# Altium schematic sheet one_side_term_J4.SchDoc
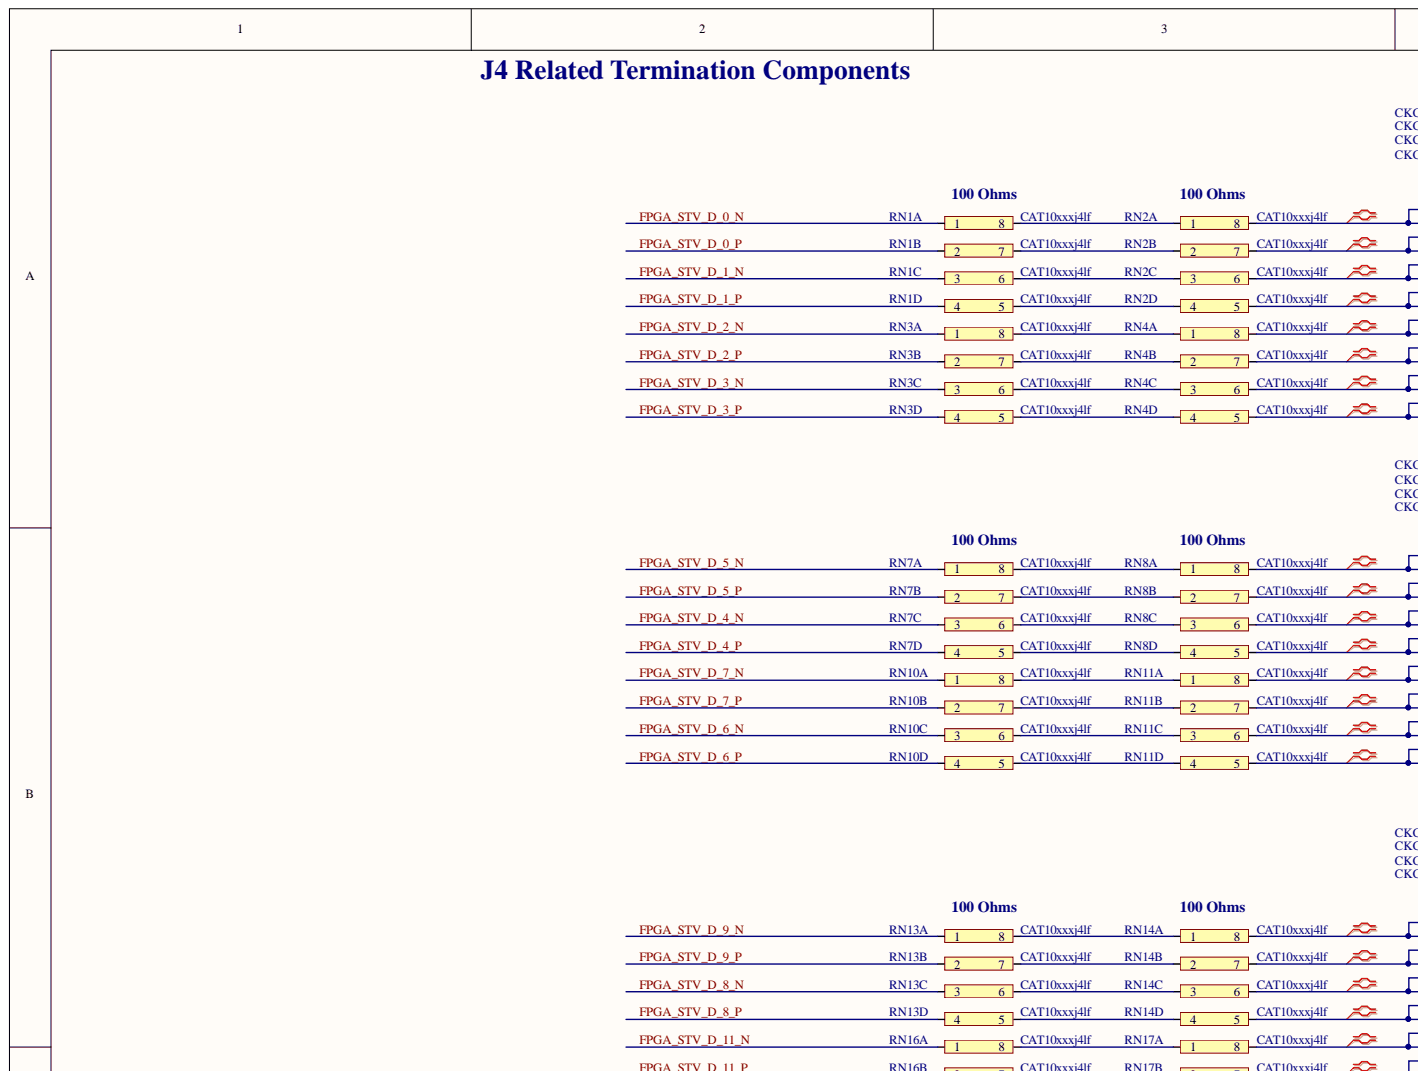
[diagram p0: one_side_term_J4.SchDoc, top left]
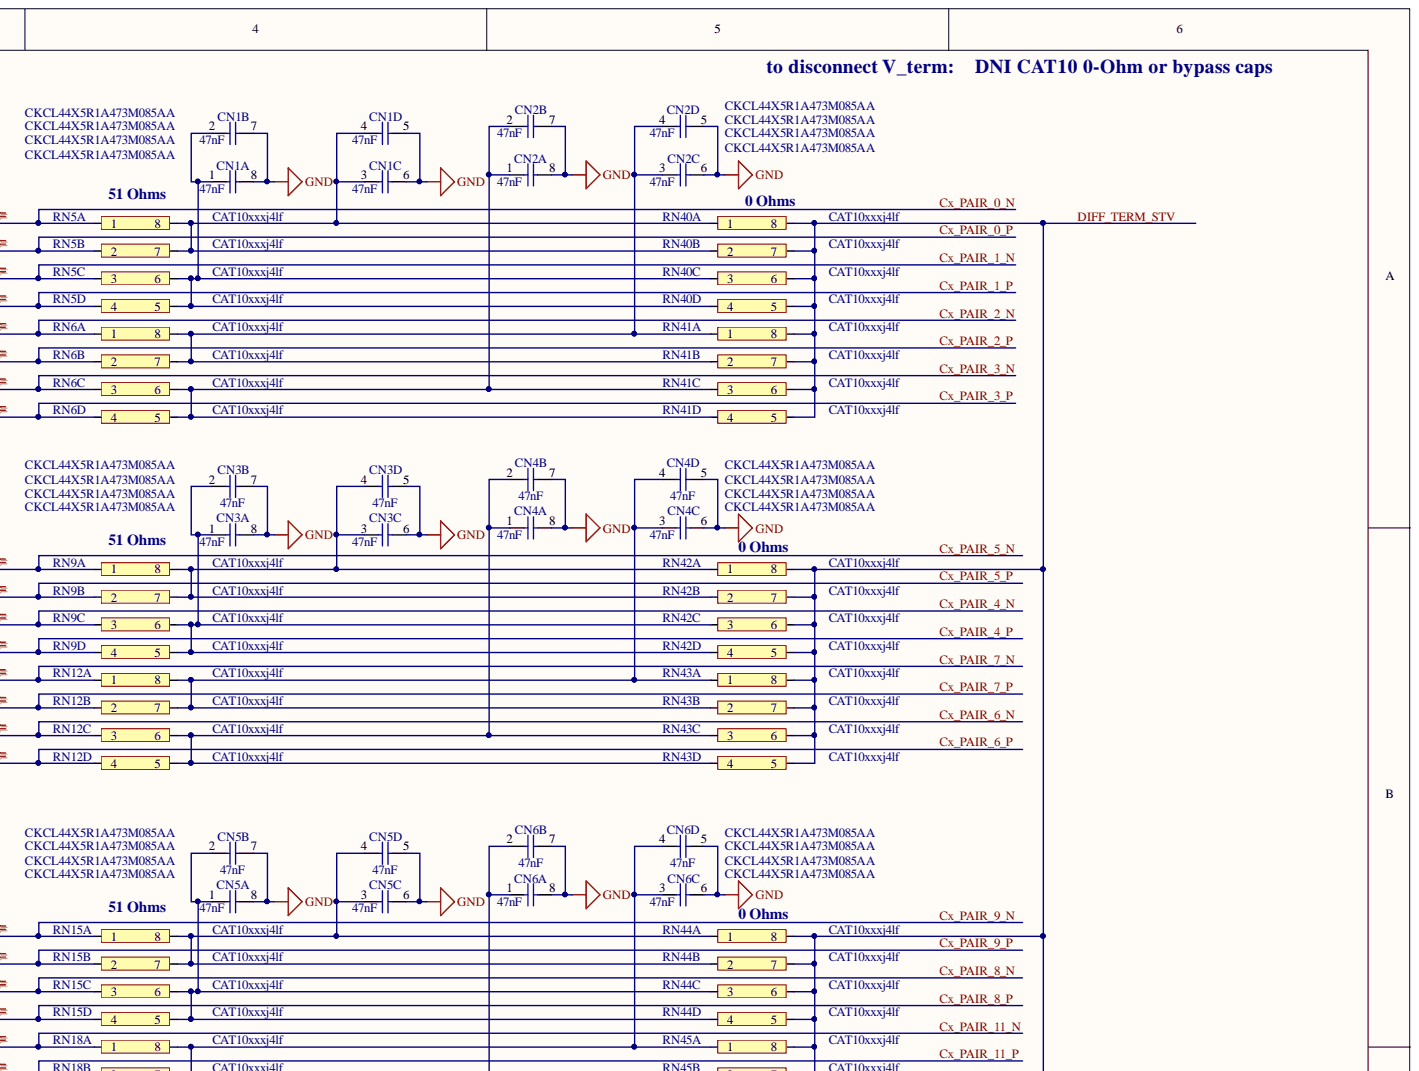
[diagram p1: one_side_term_J4.SchDoc, top right]
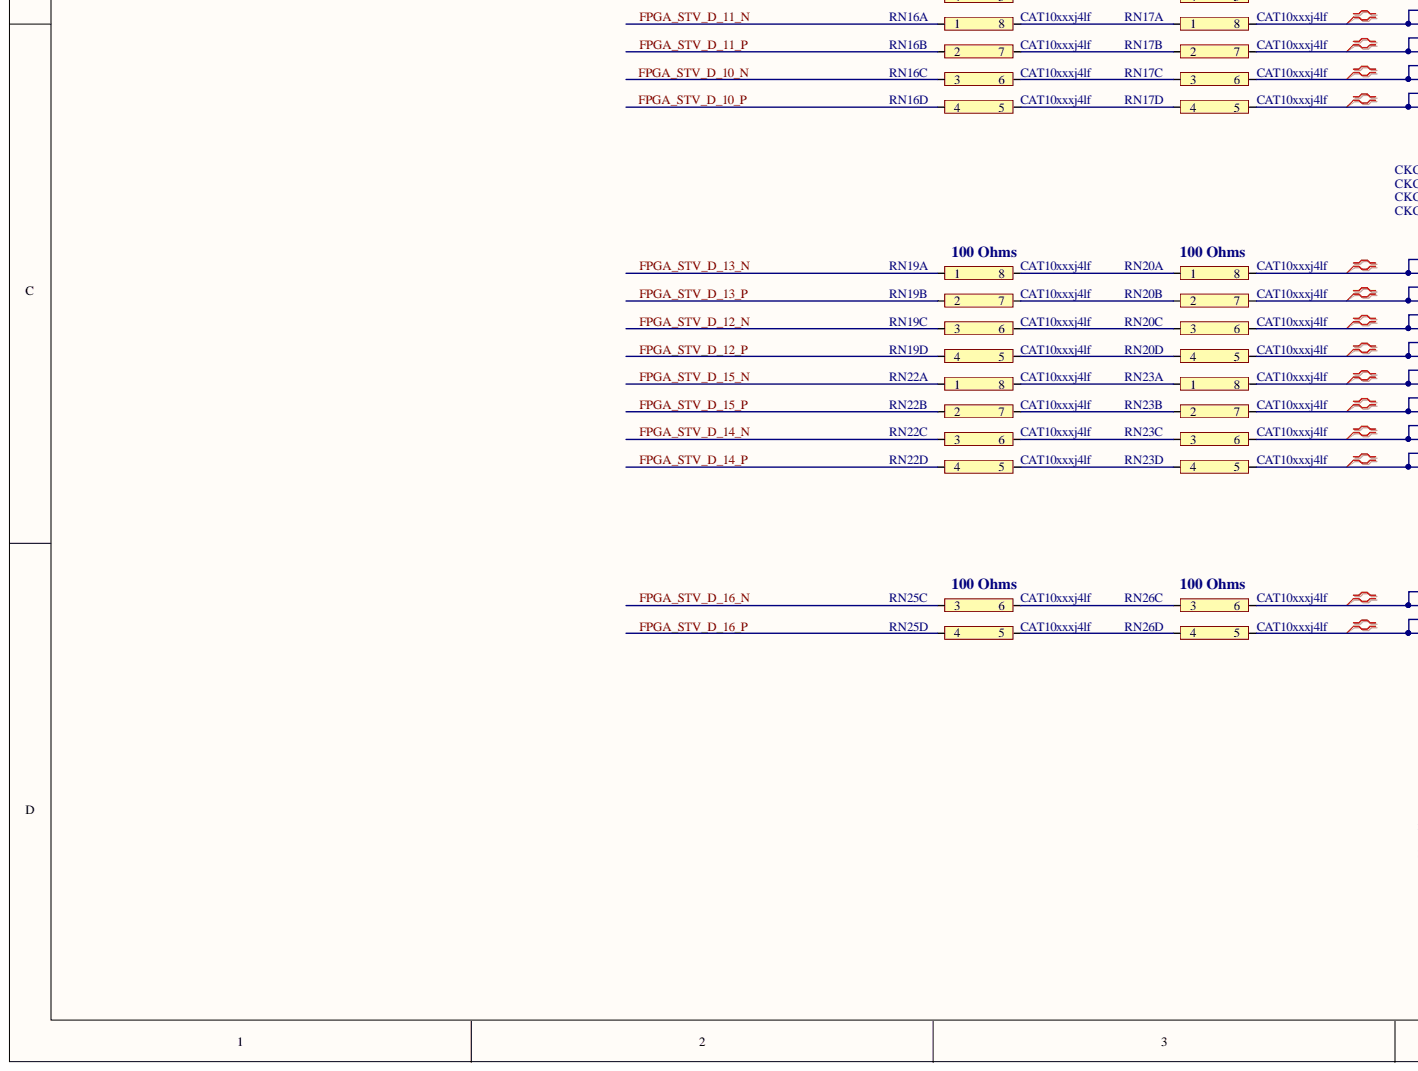
[diagram p2: one_side_term_J4.SchDoc, bottom left]
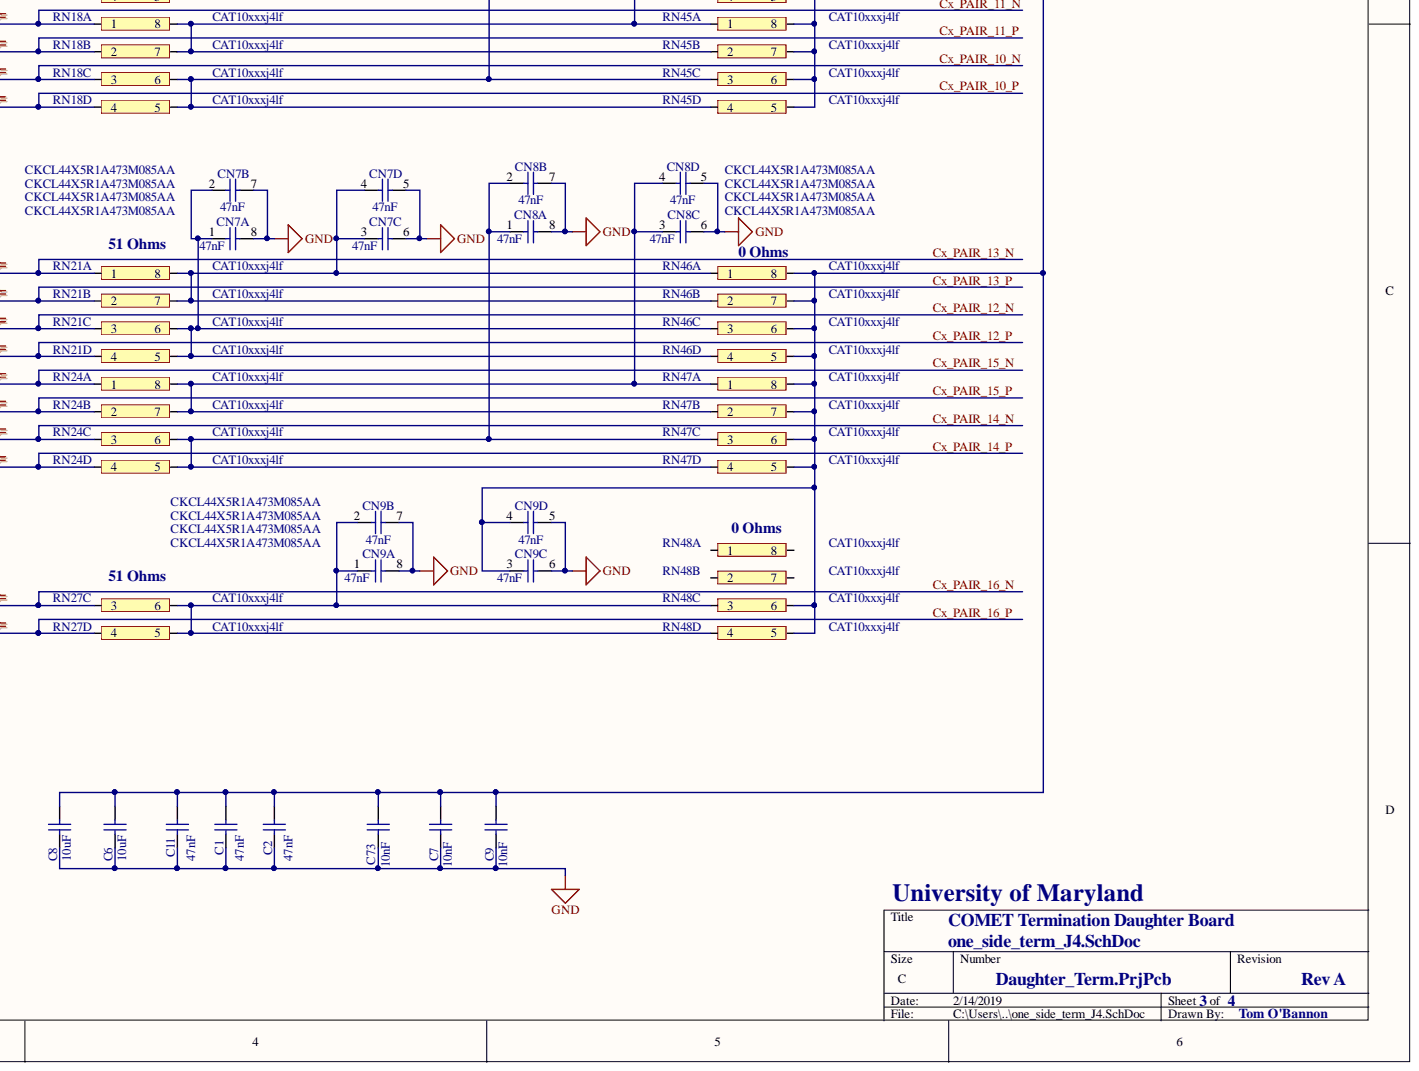
[diagram p3: one_side_term_J4.SchDoc, bottom right]

## Parts
C1  47nF  [CAPC2012X135N]
C2  47nF  [CAPC2012X135N]
C6  10uF  [CAPC2012X135N]
C7  10nF  [CAPC2012X135N]
C8  10uF  [CAPC2012X135N]
C9  10nF  [CAPC2012X135N]
C11  47nF  [CAPC2012X135N]
C73  10nF  [CAPC2012X135N]
CN1  47nF  Manufacturer Part Number: CKCL44X5R1A473M085AA  [CAPCAF50P125X200X100-8N]  (parts A,B,C,D)
CN2  47nF  Manufacturer Part Number: CKCL44X5R1A473M085AA  [CAPCAF50P125X200X100-8N]  (parts A,B,C,D)
CN3  47nF  Manufacturer Part Number: CKCL44X5R1A473M085AA  [CAPCAF50P125X200X100-8N]  (parts A,B,C,D)
CN4  47nF  Manufacturer Part Number: CKCL44X5R1A473M085AA  [CAPCAF50P125X200X100-8N]  (parts A,B,C,D)
CN5  47nF  Manufacturer Part Number: CKCL44X5R1A473M085AA  [CAPCAF50P125X200X100-8N]  (parts A,B,C,D)
CN6  47nF  Manufacturer Part Number: CKCL44X5R1A473M085AA  [CAPCAF50P125X200X100-8N]  (parts A,B,C,D)
CN7  47nF  Manufacturer Part Number: CKCL44X5R1A473M085AA  [CAPCAF50P125X200X100-8N]  (parts A,B,C,D)
CN8  47nF  Manufacturer Part Number: CKCL44X5R1A473M085AA  [CAPCAF50P125X200X100-8N]  (parts A,B,C,D)
CN9  47nF  Manufacturer Part Number: CKCL44X5R1A473M085AA  [CAPCAF50P125X200X100-8N]  (parts A,B,C,D)
RN1  CAT10xxxj4lf  [CAT10xxxj4lf]  (parts A,B,C,D)
RN2  CAT10xxxj4lf  [CAT10xxxj4lf]  (parts A,B,C,D)
RN3  CAT10xxxj4lf  [CAT10xxxj4lf]  (parts A,B,C,D)
RN4  CAT10xxxj4lf  [CAT10xxxj4lf]  (parts A,B,C,D)
RN5  CAT10xxxj4lf  [CAT10xxxj4lf]  (parts A,B,C,D)
RN6  CAT10xxxj4lf  [CAT10xxxj4lf]  (parts A,B,C,D)
RN7  CAT10xxxj4lf  [CAT10xxxj4lf]  (parts A,B,C,D)
RN8  CAT10xxxj4lf  [CAT10xxxj4lf]  (parts A,B,C,D)
RN9  CAT10xxxj4lf  [CAT10xxxj4lf]  (parts A,B,C,D)
RN10  CAT10xxxj4lf  [CAT10xxxj4lf]  (parts A,B,C,D)
RN11  CAT10xxxj4lf  [CAT10xxxj4lf]  (parts A,B,C,D)
RN12  CAT10xxxj4lf  [CAT10xxxj4lf]  (parts A,B,C,D)
RN13  CAT10xxxj4lf  [CAT10xxxj4lf]  (parts A,B,C,D)
RN14  CAT10xxxj4lf  [CAT10xxxj4lf]  (parts A,B,C,D)
RN15  CAT10xxxj4lf  [CAT10xxxj4lf]  (parts A,B,C,D)
RN16  CAT10xxxj4lf  [CAT10xxxj4lf]  (parts A,B,C,D)
RN17  CAT10xxxj4lf  [CAT10xxxj4lf]  (parts A,B,C,D)
RN18  CAT10xxxj4lf  [CAT10xxxj4lf]  (parts A,B,C,D)
RN19  CAT10xxxj4lf  [CAT10xxxj4lf]  (parts A,B,C,D)
RN20  CAT10xxxj4lf  [CAT10xxxj4lf]  (parts A,B,C,D)
RN21  CAT10xxxj4lf  [CAT10xxxj4lf]  (parts A,B,C,D)
RN22  CAT10xxxj4lf  [CAT10xxxj4lf]  (parts A,B,C,D)
RN23  CAT10xxxj4lf  [CAT10xxxj4lf]  (parts A,B,C,D)
RN24  CAT10xxxj4lf  [CAT10xxxj4lf]  (parts A,B,C,D)
RN25  CAT10xxxj4lf  [CAT10xxxj4lf]  (parts C,D)
RN26  CAT10xxxj4lf  [CAT10xxxj4lf]  (parts C,D)
RN27  CAT10xxxj4lf  [CAT10xxxj4lf]  (parts C,D)
RN40  CAT10xxxj4lf  [CAT10xxxj4lf]  (parts A,B,C,D)
RN41  CAT10xxxj4lf  [CAT10xxxj4lf]  (parts A,B,C,D)
RN42  CAT10xxxj4lf  [CAT10xxxj4lf]  (parts A,B,C,D)
RN43  CAT10xxxj4lf  [CAT10xxxj4lf]  (parts A,B,C,D)
RN44  CAT10xxxj4lf  [CAT10xxxj4lf]  (parts A,B,C,D)
RN45  CAT10xxxj4lf  [CAT10xxxj4lf]  (parts A,B,C,D)
RN46  CAT10xxxj4lf  [CAT10xxxj4lf]  (parts A,B,C,D)
RN47  CAT10xxxj4lf  [CAT10xxxj4lf]  (parts A,B,C,D)
RN48  CAT10xxxj4lf  [CAT10xxxj4lf]  (parts A,B,C,D)

## Nets
GND: C1.1 C2.1 C6.1 C7.1 C8.1 C9.1 C11.1 C73.1 CN1.5 CN1.6 CN1.7 CN1.8 CN2.5 CN2.6 CN2.7 CN2.8 CN3.5 CN3.6 CN3.7 CN3.8 CN4.5 CN4.6 CN4.7 CN4.8 CN5.5 CN5.6 CN5.7 CN5.8 CN6.5 CN6.6 CN6.7 CN6.8 CN7.5 CN7.6 CN7.7 CN7.8 CN8.5 CN8.6 CN8.7 CN8.8 CN9.5 CN9.6 CN9.7 CN9.8
CX_PAIR_0_N: RN2.8 RN5.1
CX_PAIR_0_P: RN2.7 RN5.2
CX_PAIR_1_N: RN2.6 RN5.3
CX_PAIR_1_P: RN2.5 RN5.4
CX_PAIR_2_N: RN4.8 RN6.1
CX_PAIR_2_P: RN4.7 RN6.2
CX_PAIR_3_N: RN4.6 RN6.3
CX_PAIR_3_P: RN4.5 RN6.4
CX_PAIR_4_N: RN8.6 RN9.3
CX_PAIR_4_P: RN8.5 RN9.4
CX_PAIR_5_N: RN8.8 RN9.1
CX_PAIR_5_P: RN8.7 RN9.2
CX_PAIR_6_N: RN11.6 RN12.3
CX_PAIR_6_P: RN11.5 RN12.4
CX_PAIR_7_N: RN11.8 RN12.1
CX_PAIR_7_P: RN11.7 RN12.2
CX_PAIR_8_N: RN14.6 RN15.3
CX_PAIR_8_P: RN14.5 RN15.4
CX_PAIR_9_N: RN14.8 RN15.1
CX_PAIR_9_P: RN14.7 RN15.2
CX_PAIR_10_N: RN17.6 RN18.3
CX_PAIR_10_P: RN17.5 RN18.4
CX_PAIR_11_N: RN17.8 RN18.1
CX_PAIR_11_P: RN17.7 RN18.2
CX_PAIR_12_N: RN20.6 RN21.3
CX_PAIR_12_P: RN20.5 RN21.4
CX_PAIR_13_N: RN20.8 RN21.1
CX_PAIR_13_P: RN20.7 RN21.2
CX_PAIR_14_N: RN23.6 RN24.3
CX_PAIR_14_P: RN23.5 RN24.4
CX_PAIR_15_N: RN23.8 RN24.1
CX_PAIR_15_P: RN23.7 RN24.2
CX_PAIR_16_N: RN26.6 RN27.3
CX_PAIR_16_P: RN26.5 RN27.4
DIFF_TERM_STV: C1.2 C2.2 C6.2 C7.2 C8.2 C9.2 C11.2 C73.2 CN9.3 CN9.4 RN40.5 RN40.6 RN40.7 RN40.8 RN41.5 RN41.6 RN41.7 RN41.8 RN42.5 RN42.6 RN42.7 RN42.8 RN43.5 RN43.6 RN43.7 RN43.8 RN44.5 RN44.6 RN44.7 RN44.8 RN45.5 RN45.6 RN45.7 RN45.8 RN46.5 RN46.6 RN46.7 RN46.8 RN47.5 RN47.6 RN47.7 RN47.8 RN48.5 RN48.6
FPGA_STV_D_0_N: RN1.1
FPGA_STV_D_0_P: RN1.2
FPGA_STV_D_1_N: RN1.3
FPGA_STV_D_1_P: RN1.4
FPGA_STV_D_2_N: RN3.1
FPGA_STV_D_2_P: RN3.2
FPGA_STV_D_3_N: RN3.3
FPGA_STV_D_3_P: RN3.4
FPGA_STV_D_4_N: RN7.3
FPGA_STV_D_4_P: RN7.4
FPGA_STV_D_5_N: RN7.1
FPGA_STV_D_5_P: RN7.2
FPGA_STV_D_6_N: RN10.3
FPGA_STV_D_6_P: RN10.4
FPGA_STV_D_7_N: RN10.1
FPGA_STV_D_7_P: RN10.2
FPGA_STV_D_8_N: RN13.3
FPGA_STV_D_8_P: RN13.4
FPGA_STV_D_9_N: RN13.1
FPGA_STV_D_9_P: RN13.2
FPGA_STV_D_10_N: RN16.3
FPGA_STV_D_10_P: RN16.4
FPGA_STV_D_11_N: RN16.1
FPGA_STV_D_11_P: RN16.2
FPGA_STV_D_12_N: RN19.3
FPGA_STV_D_12_P: RN19.4
FPGA_STV_D_13_N: RN19.1
FPGA_STV_D_13_P: RN19.2
FPGA_STV_D_14_N: RN22.3
FPGA_STV_D_14_P: RN22.4
FPGA_STV_D_15_N: RN22.1
FPGA_STV_D_15_P: RN22.2
FPGA_STV_D_16_N: RN25.3
FPGA_STV_D_16_P: RN25.4
NetCN1_1: CN1.1 CN1.2 RN5.5 RN5.6 RN40.3 RN40.4
NetCN1_3: CN1.3 CN1.4 RN5.7 RN5.8 RN40.1 RN40.2
NetCN2_1: CN2.1 CN2.2 RN6.5 RN6.6 RN41.3 RN41.4
NetCN2_3: CN2.3 CN2.4 RN6.7 RN6.8 RN41.1 RN41.2
NetCN3_1: CN3.1 CN3.2 RN9.5 RN9.6 RN42.3 RN42.4
NetCN3_3: CN3.3 CN3.4 RN9.7 RN9.8 RN42.1 RN42.2
NetCN4_1: CN4.1 CN4.2 RN12.5 RN12.6 RN43.3 RN43.4
NetCN4_3: CN4.3 CN4.4 RN12.7 RN12.8 RN43.1 RN43.2
NetCN5_1: CN5.1 CN5.2 RN15.5 RN15.6 RN44.3 RN44.4
NetCN5_3: CN5.3 CN5.4 RN15.7 RN15.8 RN44.1 RN44.2
NetCN6_1: CN6.1 CN6.2 RN18.5 RN18.6 RN45.3 RN45.4
NetCN6_3: CN6.3 CN6.4 RN18.7 RN18.8 RN45.1 RN45.2
NetCN7_1: CN7.1 CN7.2 RN21.5 RN21.6 RN46.3 RN46.4
NetCN7_3: CN7.3 CN7.4 RN21.7 RN21.8 RN46.1 RN46.2
NetCN8_1: CN8.1 CN8.2 RN24.5 RN24.6 RN47.3 RN47.4
NetCN8_3: CN8.3 CN8.4 RN24.7 RN24.8 RN47.1 RN47.2
NetCN9_1: CN9.1 CN9.2 RN27.5 RN27.6 RN48.3 RN48.4
NetRN1_5: RN1.5 RN2.4
NetRN1_6: RN1.6 RN2.3
NetRN1_7: RN1.7 RN2.2
NetRN1_8: RN1.8 RN2.1
NetRN3_5: RN3.5 RN4.4
NetRN3_6: RN3.6 RN4.3
NetRN3_7: RN3.7 RN4.2
NetRN3_8: RN3.8 RN4.1
NetRN7_5: RN7.5 RN8.4
NetRN7_6: RN7.6 RN8.3
NetRN7_7: RN7.7 RN8.2
NetRN7_8: RN7.8 RN8.1
NetRN10_5: RN10.5 RN11.4
NetRN10_6: RN10.6 RN11.3
NetRN10_7: RN10.7 RN11.2
NetRN10_8: RN10.8 RN11.1
NetRN13_5: RN13.5 RN14.4
NetRN13_6: RN13.6 RN14.3
NetRN13_7: RN13.7 RN14.2
NetRN13_8: RN13.8 RN14.1
NetRN16_5: RN16.5 RN17.4
NetRN16_6: RN16.6 RN17.3
NetRN16_7: RN16.7 RN17.2
NetRN16_8: RN16.8 RN17.1
NetRN19_5: RN19.5 RN20.4
NetRN19_6: RN19.6 RN20.3
NetRN19_7: RN19.7 RN20.2
NetRN19_8: RN19.8 RN20.1
NetRN22_5: RN22.5 RN23.4
NetRN22_6: RN22.6 RN23.3
NetRN22_7: RN22.7 RN23.2
NetRN22_8: RN22.8 RN23.1
NetRN25_5: RN25.5 RN26.4
NetRN25_6: RN25.6 RN26.3

Not connected: RN48 1 2 7 8

## Library data of the parts
C1 C2 C11 = CC0805_100NF_50V_10%_X7R: Non-polarised capacitor; Manufacturer: GENERIC; Manufacturer1 Part Number: B37941K5104K62; Part Description: SMD Multilayer Chip Ceramic Capacitor; Database Table Name: Capacitors SMD; TC: X7R; Tolerance: ±10%; Voltage: 10V; Case: 0805; Component Kind: Standard; Component Type: Standard; CreateDate: 12/3/2007; HelpURL: \\cern.ch\dfs\Applications\Altium\Datasheets\CC0805_X7R_EPCOS.pdf; LatestRevisionDate: 12/3/2007; Library Name: Capacitors.DbLib; Manufacturer1 ComponentHeight: 1.35mm; Manufacturer1 Example: EPCOS; Manufacturer Part Number: CC0805_100NF_50V_10%_X7R; Mounted: Yes; Part Number: CC0805_100NF_50V_10%_X7R; Pin Count: 2; SMD: Yes; Socket: No; Status: Preferred; Table: Capacitors SMD; Value: 47nF; Author: CERN DEM JLC
C6 C8 = CC0805_10UF_10V_10%_X7R: Non-polarised capacitor; Manufacturer: GENERIC; Manufacturer1 Part Number: GRM21BR71A106KE51L; Part Description: SMD Multilayer Chip Ceramic Capacitor; Database Table Name: Capacitors SMD; TC: X7R; Tolerance: ±10%; Voltage: 10V; Case: 0805; Component Kind: Standard; Component Type: Standard; CreateDate: 4/30/2012; HelpURL: \\cern.ch\dfs\Applications\Altium\Datasheets\CC0805_X7R_MURATA.pdf; LatestRevisionDate: 4/30/2012; Library Name: Capacitors.DbLib; Manufacturer1 ComponentHeight: 1.35mm; Manufacturer1 Example: MURATA; Manufacturer Part Number: CC0805_10UF_10V_10%_X7R; Mounted: Yes; Part Number: CC0805_10UF_10V_10%_X7R; Pin Count: 2; SMD: Yes; Socket: No; Status: Preferred; Table: Capacitors SMD; Value: 10uF; Author: CERN DEM JLC
C7 C9 C73 = CC0805_10NF_50V_5%_X7R: Non-polarised capacitor; Manufacturer: GENERIC; Manufacturer1 Part Number: 08055C103JAT2A; Part Description: SMD Multilayer Chip Ceramic Capacitor; Database Table Name: Capacitors SMD; TC: X7R; Tolerance: ±5%; Voltage: 50V; Case: 0805; Component Kind: Standard; Component Type: Standard; CreateDate: 1/18/2011; HelpURL: \\cern.ch\dfs\Applications\Altium\Datasheets\CC0805_X7R_AVX.pdf; LatestRevisionDate: 1/18/2011; Library Name: Capacitors.DbLib; Manufacturer1 ComponentHeight: 0.94mm; Manufacturer1 Example: AVX; Manufacturer Part Number: CC0805_10NF_50V_5%_X7R; Mounted: Yes; Part Number: CC0805_10NF_50V_5%_X7R; Pin Count: 2; SMD: Yes; Socket: No; Status: None; Table: Capacitors SMD; Value: 10nF; Author: CERN DEM JLC
CN1-CN9 = CN_100NF_6.3V_20%_X5R_TDK_CKCL44: DIL8 Capacitor Network 4 Isolated Capacitors; Manufacturer: TDK; Part Description: Multilayer Ceramic Chip Capacitors Array (4 Isolated Capacitors); Database Table Name: Capacitors Networks SMD; TC: X5R; Tolerance: ±20%; Voltage: 10V; Case: 2012; ComponentHeight: 1mm; Component Kind: Standard; Component Type: Standard; CreateDate: 12/9/2010; HelpURL: \\cern.ch\dfs\Applications\Altium\Datasheets\CN_TDK_CKCL44.pdf; LatestRevisionDate: 12/9/2010; Library Name: Capacitors.DbLib; Mounted: Yes; PackageDescription: Capacitor,non-polarized,Chip Array,0.50mm pitch;8 pin,1.25mm W X 2.00mm L X 1.00mm H, IPC Medium Density; Part Number: CN_100NF_6.3V_20%_X5R_TDK_CKCL44; Pin Count: 8; SMD: Yes; Socket: No; Status: None; Table: Capacitors Networks SMD; Value: 47nF; Author: CERN DEM JLC
RN1-RN27 RN40-RN48 = CAT10xxxj4lf: Component Kind: Standard; Library Name: Intg_Lib_ResNets.IntLib
